# Altium schematic sheet SC20100S-Connectors.SchDoc(SC20100S-Connectors)
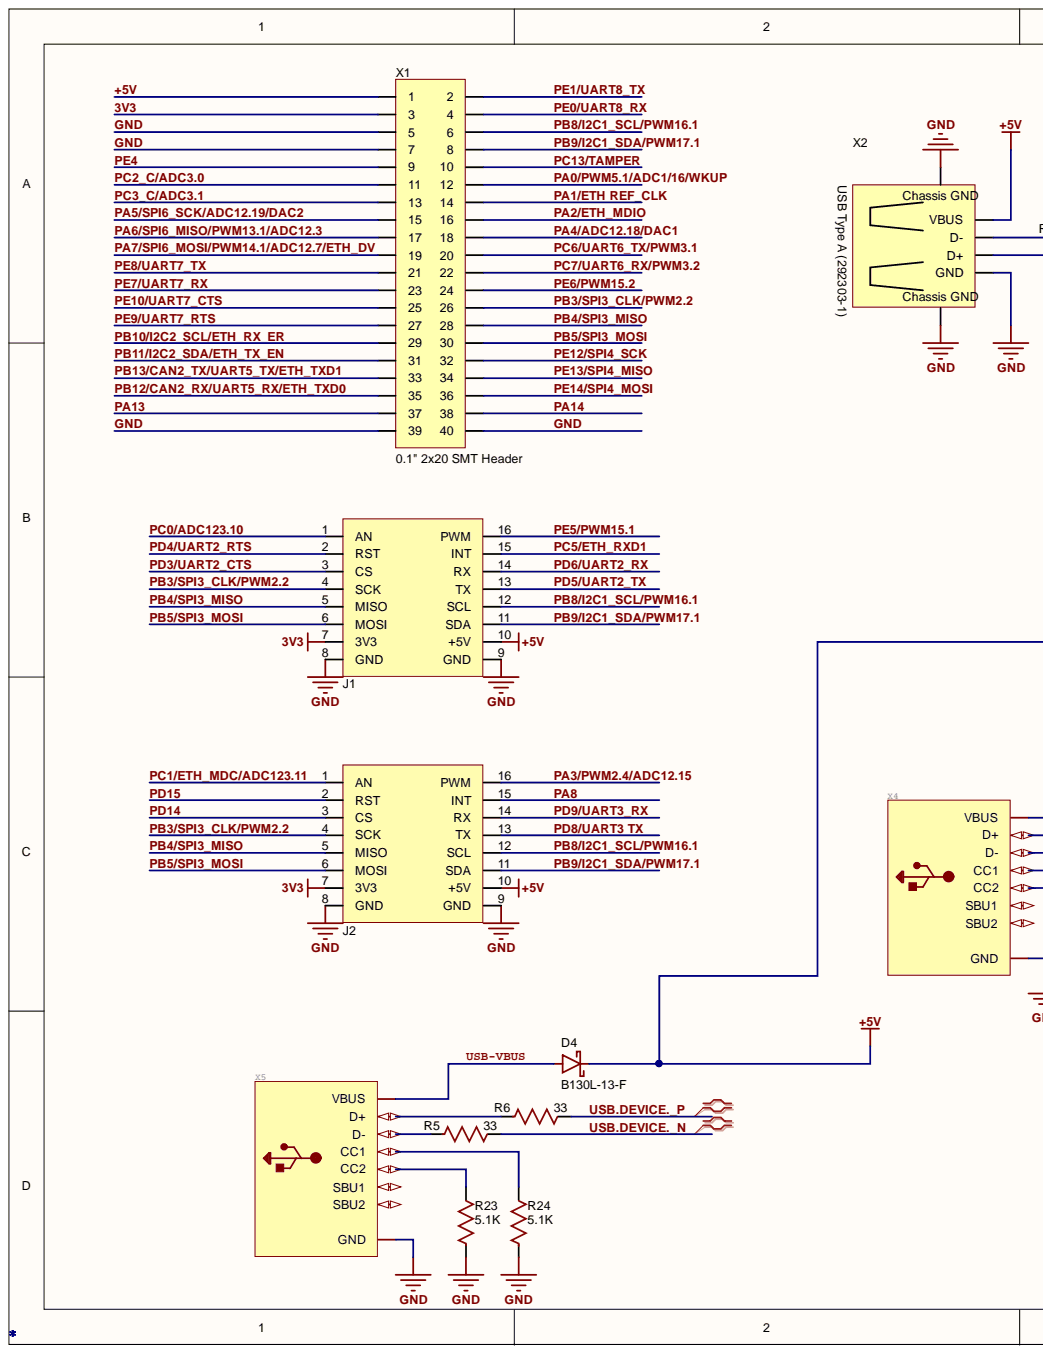
[diagram p0: SC20100S-Connectors.SchDoc, left]
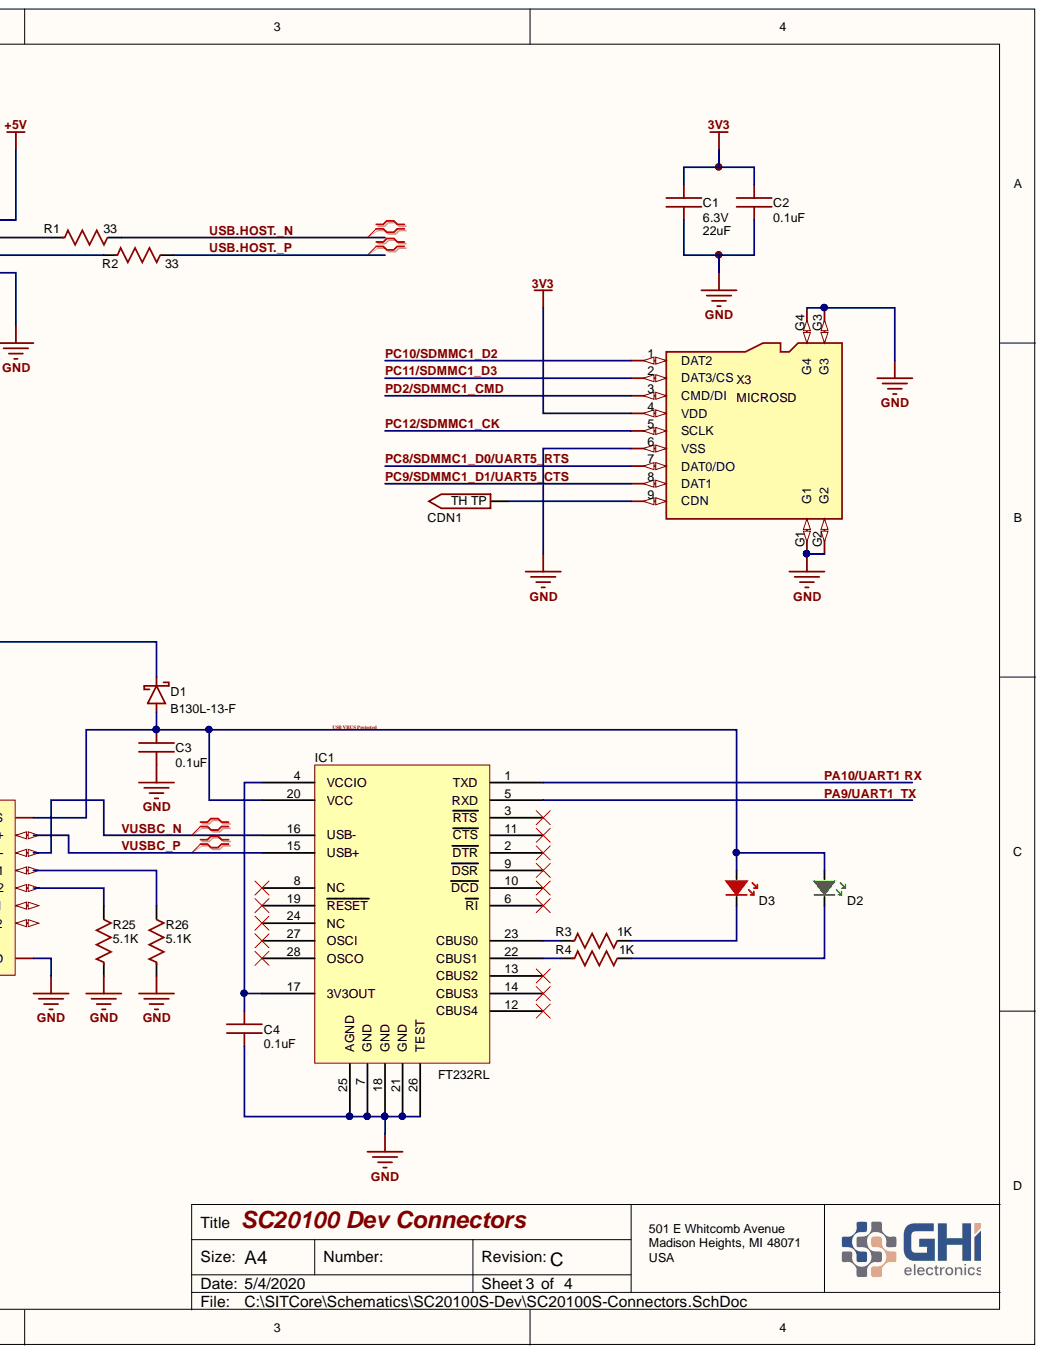
[diagram p1: SC20100S-Connectors.SchDoc, right]

## Parts
C1  value 22uF  Voltage: 6.3V  [C0805]  (hidden comment: 0805 Cap 22uF)
C2  value 0.1uF  [C0603 (1608 Metric)]  (hidden comment: 0603 Cap 0.1uF)
C3  value 0.1uF  [C0603 (1608 Metric)]  (hidden comment: 0603 Cap 0.1uF)
C4  value 0.1uF  [C0603 (1608 Metric)]  (hidden comment: 0603 Cap 0.1uF)
CDN1  TH Testpad 32mil (32mil ar)  [32mil TH]  (value not drawn)  (no BOM)
D1  B130L-13-F  [SMA]
D2  0603 LED Green  [0603 LED Green]  (value not drawn)
D3  0603 LED Red  [0603 LED Red]  (value not drawn)
D4  B130L-13-F  [SMA]
IC1  FT232RL  [SSOP28]
J1  mikroBUS SMT  [mikroBus - SMT]  (value not drawn)
J2  mikroBUS SMT  [mikroBus - SMT]  (value not drawn)
R1  value 33  [R0603 (1608 Metric)]  (hidden comment: 0603 33)
R2  value 33  [R0603 (1608 Metric)]  (hidden comment: 0603 33)
R3  value 1K  [R0603 (1608 Metric)]  (hidden comment: 0603 1K)
R4  value 1K  [R0603 (1608 Metric)]  (hidden comment: 0603 1K)
R5  value 33  [R0603 (1608 Metric)]  (hidden comment: 0603 33)
R6  value 33  [R0603 (1608 Metric)]  (hidden comment: 0603 33)
R23  value 5.1K  [R0402]  (hidden comment: 0402 Res 5.1K)
R24  value 5.1K  [R0402]  (hidden comment: 0402 Res 5.1K)
R25  value 5.1K  [R0402]  (hidden comment: 0402 Res 5.1K)
R26  value 5.1K  [R0402]  (hidden comment: 0402 Res 5.1K)
X1  0.1" 2x20 SMT Header  [SMT 0.1" 2x20]
X2  USB Type A (292303-1)  [AMP 292303-1]
X3  MICROSD  [MICROSD]
X4  (no value)  [GCT_USB4105_revA]
X5  (no value)  [GCT_USB4105_revA]

## Nets
3V3: C1.2 C2.2 J1.7(3V3) J2.7(3V3) X1.3 X3.4(VDD)
+5V: D1.K D4.K J1.10(+5V) J2.10(+5V) X1.1 X2.1(VBUS)
GND: C1.1 C2.1 C3.1 C4.1 IC1.7(GND) IC1.18(GND) IC1.21(GND) IC1.25(AGND) IC1.26(TEST) J1.8(GND) J1.9(GND) J2.8(GND) J2.9(GND) R23.1 R24.1 R25.1 R26.1 X1.5 X1.7 X1.39 X1.40 X2.4(GND) X2.CG1 X2.CG2 X3.6(VSS) X3.G1 X3.G2 X3.G3 X3.G4 X4.GND X5.GND
PA0/PWM5.1/ADC1/16/WKUP: X1.12
PA1/ETH REF_CLK: X1.14
PA2/ETH_MDIO: X1.16
PA3/PWM2.4/ADC12.15: J2.16(PWM)
PA4/ADC12.18/DAC1: X1.18
PA5/SPI6_SCK/ADC12.19/DAC2: X1.15
PA6/SPI6_MISO/PWM13.1/ADC12.3: X1.17
PA7/SPI6_MOSI/PWM14.1/ADC12.7/ETH_DV: X1.19
PA8: J2.15(INT)
PA9/UART1_TX: IC1.5(RXD)
PA10/UART1 RX: IC1.1(TXD)
PA13: X1.37
PA14: X1.38
PB3/SPI3_CLK/PWM2.2: J1.4(SCK) J2.4(SCK) X1.26
PB4/SPI3_MISO: J1.5(MISO) J2.5(MISO) X1.28
PB5/SPI3_MOSI: J1.6(MOSI) J2.6(MOSI) X1.30
PB8/I2C1_SCL/PWM16.1: J1.12(SCL) J2.12(SCL) X1.6
PB9/I2C1_SDA/PWM17.1: J1.11(SDA) J2.11(SDA) X1.8
PB10/I2C2_SCL/ETH_RX_ER: X1.29
PB11/I2C2_SDA/ETH_TX_EN: X1.31
PB12/CAN2_RX/UART5_RX/ETH_TXD0: X1.35
PB13/CAN2_TX/UART5_TX/ETH_TXD1: X1.33
PC0/ADC123.10: J1.1(AN)
PC1/ETH_MDC/ADC123.11: J2.1(AN)
PC2_C/ADC3.0: X1.11
PC3_C/ADC3.1: X1.13
PC5/ETH_RXD1: J1.15(INT)
PC6/UART6_TX/PWM3.1: X1.20
PC7/UART6_RX/PWM3.2: X1.22
PC8/SDMMC1_D0/UART5_RTS: X3.7(DAT0/DO)
PC9/SDMMC1_D1/UART5_CTS: X3.8(DAT1)
PC10/SDMMC1_D2: X3.1(DAT2)
PC11/SDMMC1_D3: X3.2(DAT3/CS)
PC12/SDMMC1_CK: X3.5(SCLK)
PC13/TAMPER: X1.10
PD2/SDMMC1_CMD: X3.3(CMD/DI)
PD3/UART2_CTS: J1.3(CS)
PD4/UART2_RTS: J1.2(RST)
PD5/UART2_TX: J1.13(TX)
PD6/UART2_RX: J1.14(RX)
PD8/UART3 TX: J2.13(TX)
PD9/UART3_RX: J2.14(RX)
PD14: J2.3(CS)
PD15: J2.2(RST)
PE0/UART8_RX: X1.4
PE1/UART8_TX: X1.2
PE4: X1.9
PE5/PWM15.1: J1.16(PWM)
PE6/PWM15.2: X1.24
PE7/UART7_RX: X1.23
PE8/UART7_TX: X1.21
PE9/UART7_RTS: X1.27
PE10/UART7_CTS: X1.25
PE12/SPI4_SCK: X1.32
PE13/SPI4_MISO: X1.34
PE14/SPI4_MOSI: X1.36
USB VBUS Protected: C3.2 D1.A D2.2 D3.2 IC1.20(VCC) X4.A4B9(VBUS)
USB-VBUS: D4.A X5.A4B9(VBUS)
USB.DEVICE._N: R5.2
USB.DEVICE._P: R6.2
USB.HOST._N: R1.2
USB.HOST._P: R2.2
VUSBC_N: IC1.16(USB-) X4.A7B7(D-)
VUSBC_P: IC1.15(USB+) X4.A6B6(D+)
NetC4_2: C4.2 IC1.4(VCCIO) IC1.17(3V3OUT)
NetCDN1_1: CDN1.1 X3.9(CDN)
NetD2_1: D2.1 R4.2
NetD3_1: D3.1 R3.2
NetIC1_22: IC1.22(CBUS1) R4.1
NetIC1_23: IC1.23(CBUS0) R3.1
NetR1_1: R1.1 X2.2(D-)
NetR2_1: R2.1 X2.3(D+)
NetR5_1: R5.1 X5.A7B7(D-)
NetR6_1: R6.1 X5.A6B6(D+)
NetR23_2: R23.2 X5.B5(CC2)
NetR24_2: R24.2 X5.A5(CC1)
NetR25_2: R25.2 X4.B5(CC2)
NetR26_2: R26.2 X4.A5(CC1)

Not connected: IC1 2(DTR) 3(RTS) 6(RI) 8(NC) 9(DSR) 10(DCD) 11(CTS) 12(CBUS4) 13(CBUS2) 14(CBUS3) 19(RESET) 24(NC) 27(OSCI) 28(OSCO); X4 A8(SBU1) B8(SBU2); X5 A8(SBU1) B8(SBU2)

## Library data of the parts
C1 = 0805 Cap 22uF: 22uF ±20% 6.3V X5R 0805 Multilayer Ceramic Capacitors MLCC - SMD/SMT RoHS; Mfr.Part #: CL21A226MQCLRNC; Tolerance: ±20%; Package: 0805; LCSC Part #: C307530; Component Kind: Standard; Category: Ceramic; Capacitance: 22uF; Library Name: GHI-Passives.SchLib
C2-C4 = 0603 Cap 0.1uF: 0.1uF ±5% 50V X7R 0603 Multilayer Ceramic Capacitors MLCC - SMD/SMT RoHS; Mfr.Part #: CL10B104JB8NNNC; Voltage: 50V; Tolerance: ±5%; Package: 0603; LCSC Part #: C24452; Digikey: 1276-1033-1-ND; Component Kind: Standard; Category: Ceramic; Capacitance: 0.1uF; Library Name: GHI-Passives.SchLib
CDN1 = TH Testpad 32mil (32mil ar): TH Testpad 32mil (32mil annular ring); Library Name: GHI.SchLib
D1 D4 = B130L-13-F 1A Schottky SMA: Diode 1.0A Schottky Barrier Rectifier SMA; GHI Part Number: EPC-DB130L-13; Value: B130L-13-F; PartNo: B130L-13-F; Package: SMA; Library Name: GHI.SchLib; Component Type: Diode; Component Kind: Standard
D2 = 0603 LED Green: Green 567.5~576.5nm 0603 Light Emitting Diodes (LED) RoHS; Mfr.Part #: LTST-C193KGKT-5A; Manufacturer: Lite-On; Value: 0603 LED Green; Package: 0603; LCSC Part #: C125102; Component Kind: Standard; Color: Green; Library Name: GHI-Opto.SchLib
D3 = 0603 LED Red: Red 631nm 0603 Light Emitting Diodes (LED) RoHS; Mfr.Part #: LTST-C193KRKT-5A; Manufacturer: Lite-On; Value: 0603 LED Red; Package: 0603; LCSC Part #: C157741; Component Kind: Standard; Color: Red; Library Name: GHI-Opto.SchLib
IC1 = FT232RL: Future Technology Devices International Ltd. FT232R USB UART IC; Value: FT232RL; Component Kind: Standard; Library Name: GHI IC.SchLib
J1 J2 = mikroBUS SMT: Value: 218108M141; PartNo: 218108M141; Component Kind: Standard; Library Name: GHI.SchLib
R1 R2 R5 R6 = 0603 Res 33: 33 ±1% 1/10W ±100ppm/℃ 0603 Chip Resistor - Surface Mount RoHS; Mfr.Part #: RC0603FR-0733RL; Manufacturer: YAGEO; Voltage Rating: *V; Resistance Tolerance: ±1%; Resistance: 33; Power Rating: 1/10W; Package: 0603; LCSC Part #: C108661; Component Kind: Standard; Library Name: GHI-Passives.SchLib
R3 R4 = 0603 Res 1K: 1K ±5% 1/10W ±100ppm/℃ 0603 Chip Resistor - Surface Mount RoHS; Mfr.Part #: WR06X102 JTL; Manufacturer: Walsin Tech Corp; Voltage Rating: *V; Resistance Tolerance: ±5%; Resistance: 1K; Power Rating: 1/10W; Package: 0603; LCSC Part #: C384295; Component Kind: Standard; Library Name: GHI-Passives.SchLib
R23-R26 = 0402 Res 5.1K: 5.1K ±1% 1/16W ±100ppm/℃ 0402 Chip Resistor - Surface Mount RoHS; Mfr.Part #: RC0402FR-075K1L; Manufacturer: YAGEO; Voltage Rating: *V; Resistance Tolerance: ±1%; Resistance: 5.1K; Power Rating: 1/16W; Package: 0402; LCSC Part #: C105872; Component Kind: Standard; Library Name: GHI-Passives.SchLib
X1 = 2x20 Pin 0.1" SMT: Value: 2x20 Pin 0.1" SMT; Description: 2x20 Pin 0.1" SMT; Component Kind: Standard; Library Name: GHI.SchLib
X2 = USB Type A Receptacle: TE Connectivity 292303-1 USB Type A receptacle; Value: 292303-1; PartNo: 292303-1; Component Kind: Standard; Library Name: GHI Sch.SchLib
X3 = MICROSD: GateName_1: G$1; SymbolName_1: MICROSD; LibraryName: microSD; DeviceSetName: MICROSD; Component Kind: Standard; Library Name: GHI.SchLib
X4 X5 = USB_C: USB Type-C USB 2.0 Connector; VALUE: USB_C; USBC: USB C; GateName_1: G$1; SymbolName_1: USB_C; LibraryName: microbuilder; DeviceSetName: USB_C; Component Kind: Standard; : USB_C; Library Name: GHI-Connectors.SchLib
